# Altium schematic sheet 27C011_EMU.SchDoc
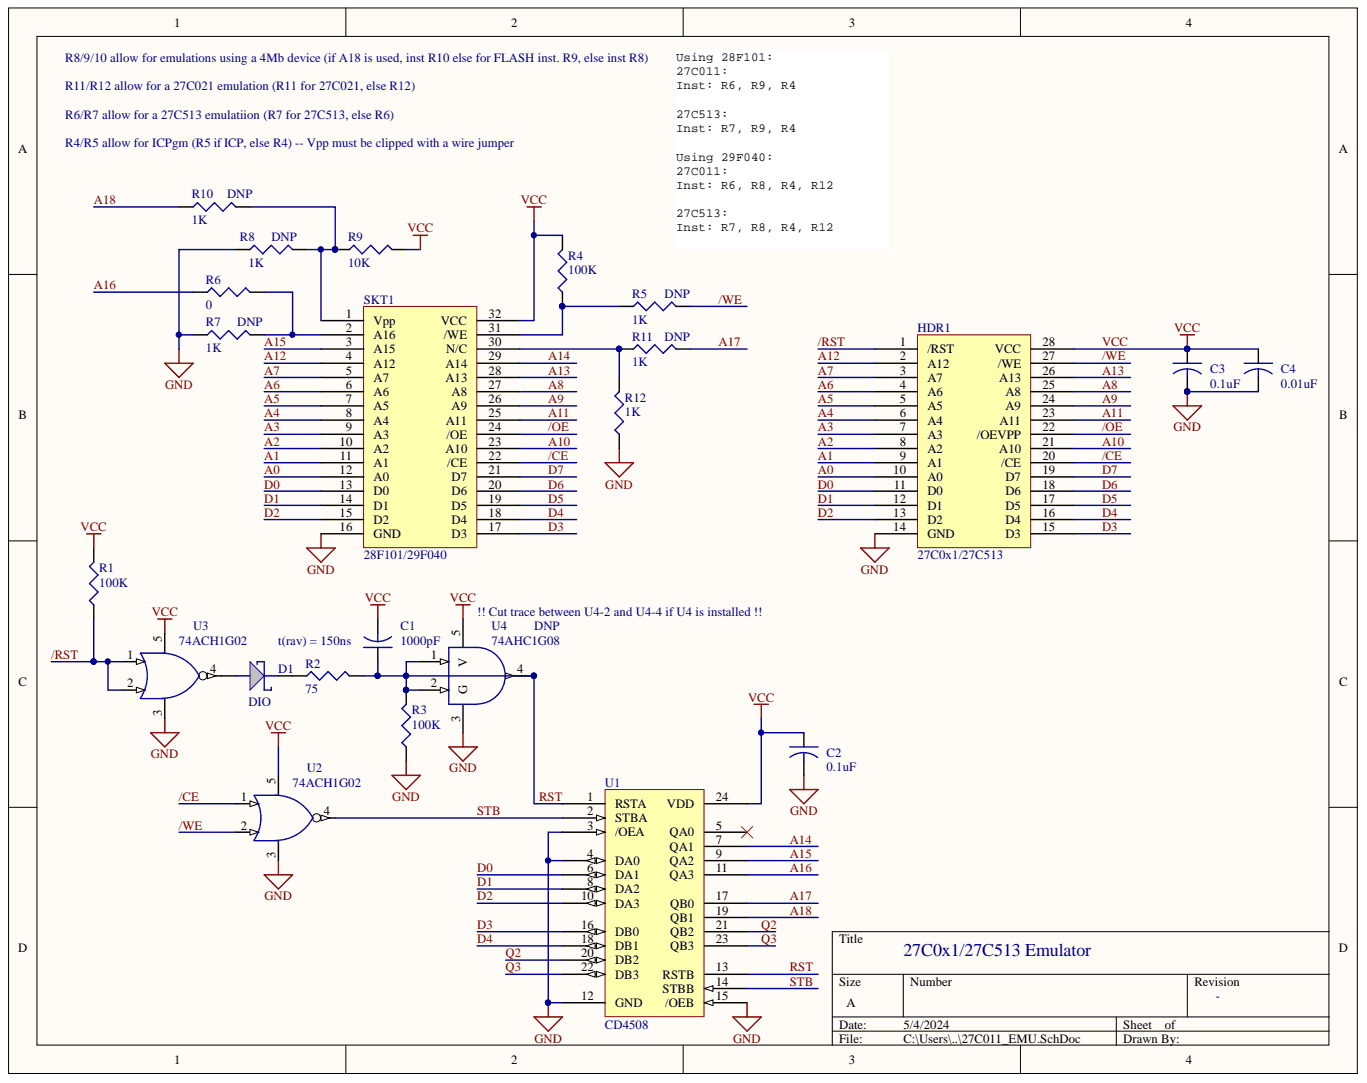
[diagram p0: 27C011_EMU.SchDoc]

## Parts
C1  1000pF  [0402]
C2  0.1uF  [0805]
C3  0.1uF  [0805]
C4  0.01uF  [0805]
D1  DIO  [SOD123]
HDR1  27C0x1/27C513  [DIP28_BOTHDR]
R1  100K  [0402]
R2  75  [0402]
R3  100K  [0402]
R4  100K  [0402]
R5  1K  [0402]
R6  0  [0402]
R7  1K  [0402]
R8  1K  [0402]
R9  10K  [0402]
R10  1K  [0402]
R11  1K  [0402]
R12  1K  [0402]
SKT1  28F101/29F040  [DIP32]
U1  CD4508  [TSSOP-24]
U2  74ACH1G02  [SC70-5]
U3  74ACH1G02  [SC70-5]
U4  74AHC1G08  [SC70-5]

## Nets
GND: C2.2 C3.2 C4.2 HDR1.14(GND) R3.1 R7.1 R8.1 R12.1 SKT1.16(GND) U1.3(/OEA) U1.4(DA0) U1.12(GND) U1.15(/OEB) U2.3 U3.3 U4.3
/CE: HDR1.20(/CE) SKT1.22(/CE) U2.1
/OE: HDR1.22(/OEVPP) SKT1.24(/OE)
/RST: HDR1.1(/RST) R1.1 U3.1 U3.2
/WE: HDR1.27(/WE) R5.2 U2.2
A0: HDR1.10(A0) SKT1.12(A0)
A1: HDR1.9(A1) SKT1.11(A1)
A2: HDR1.8(A2) SKT1.10(A2)
A3: HDR1.7(A3) SKT1.9(A3)
A4: HDR1.6(A4) SKT1.8(A4)
A5: HDR1.5(A5) SKT1.7(A5)
A6: HDR1.4(A6) SKT1.6(A6)
A7: HDR1.3(A7) SKT1.5(A7)
A8: HDR1.25(A8) SKT1.27(A8)
A9: HDR1.24(A9) SKT1.26(A9)
A10: HDR1.21(A10) SKT1.23(A10)
A11: HDR1.23(A11) SKT1.25(A11)
A12: HDR1.2(A12) SKT1.4(A12)
A13: HDR1.26(A13) SKT1.28(A13)
A14: SKT1.29(A14) U1.7(QA1)
A15: SKT1.3(A15) U1.9(QA2)
A16: R6.1 U1.11(QA3)
A17: R11.2 U1.17(QB0)
A18: R10.1 U1.19(QB1)
D0: HDR1.11(D0) SKT1.13(D0) U1.6(DA1)
D1: HDR1.12(D1) SKT1.14(D1) U1.8(DA2)
D2: HDR1.13(D2) SKT1.15(D2) U1.10(DA3)
D3: HDR1.15(D3) SKT1.17(D3) U1.16(DB0)
D4: HDR1.16(D4) SKT1.18(D4) U1.18(DB1)
D5: HDR1.17(D5) SKT1.19(D5)
D6: HDR1.18(D6) SKT1.20(D6)
D7: HDR1.19(D7) SKT1.21(D7)
Q2: U1.20(DB2) U1.21(QB2)
Q3: U1.22(DB3) U1.23(QB3)
RST: C1.1 R2.2 R3.2 U1.1(RSTA) U1.13(RSTB) U4.1 U4.2(G) U4.4
STB: U1.2(STBA) U1.14(STBB) U2.4
VCC: C1.2 C2.1 C3.1 C4.1 HDR1.28(VCC) R1.2 R4.2 R9.2 SKT1.32(VCC) U1.24(VDD) U2.5 U3.5 U4.5(V)
NetD1_1: D1.1 R2.1
NetD1_2: D1.2 U3.4
NetR4_1: R4.1 R5.1 SKT1.31(/WE)
NetR6_2: R6.2 R7.2 SKT1.2(A16)
NetR8_2: R8.2 R9.1 R10.2 SKT1.1(Vpp)
NetR11_1: R11.1 R12.2 SKT1.30(N/C)

Not connected: U1 5(QA0)

## Library data of the parts
C1 = CAP 0805: CAP, CER, 0402, 50V, 0.0022uF; MFR: Samsung EM; MPN: CL05B222KB5NNND; VOLTAGE: 50; ALT-MFR: -; ALT-MPN: -; Component Kind: Standard; DNP: -; Library Name: Galaxy SCH-jem-1.08.SchLib
C2 C3 = CAP 0805: CAP, TANT, 0805, 50V, 0.1uF; MFR: Samsung EM; MPN: CL21B104KCFSFNG; ALT-MFR: -; ALT-MPN: -; Component Kind: Standard; DNP: -; Library Name: Galaxy SCH-jem-1.08.SchLib
C4 = CAP 0805: CAP, TANT, 0805, 50V, 0.01uF; MFR: Samsung EM; MPN: CL21B103KBANNNL; ALT-MFR: -; ALT-MPN: -; Component Kind: Standard; DNP: -; Library Name: Galaxy SCH-jem-1.08.SchLib
D1 = DIODE_SCHOTTKY_SOD123: DIODE, SHTKY; MFR: Rohm; MPN: RB168MM-40TFTR; ALT-MPN: -; Component Kind: Standard; DNP: -; NOTES: -; NOTES2: -; PRI-MPN: -; Library Name: Galaxy SCH-jem-1.08.SchLib
HDR1 = 25C513: HDR, IC, DIP, 28p; ALT-MFR: Aries; ALT-MPN: 28-600-20; MFR: Ares; MPN: 28-600-10; Component Kind: Standard; Library Name: Galaxy SCH-jem-1.08.SchLib
R1 R3 R4 = R0805: RES, CHP, 0402, 1%, 100K; MFR: Yageo; MPN: RC0402FR-07100KL; ALT-MPN: -; Component Kind: Standard; DNP: -; NOTES: -; NOTES2: -; PRI-MPN: -; Library Name: Galaxy SCH-jem-1.08.SchLib
R2 = R0805: RES, CHP, 0402, 1%, 75; MFR: Yageo; MPN: RC0402FR-0775RL; ALT-MPN: -; Component Kind: Standard; DNP: -; NOTES: -; NOTES2: -; PRI-MPN: -; Library Name: Galaxy SCH-jem-1.08.SchLib
R5 R7 R8 R10-R12 = R0805: RES, CHP, 0402, 1%, 1K; MFR: Yageo; MPN: RC0402FR-071KL; ALT-MPN: -; Component Kind: Standard; NOTES: -; NOTES2: -; PRI-MPN: -; Library Name: Galaxy SCH-jem-1.08.SchLib
  R5 R7 R8 R10 R11: DNP: DNP
  R12: DNP: -
R6 = R0805: RES, CHP, 0402, 1%, 0; MFR: Yageo; MPN: RC0402FR-070RL; ALT-MPN: -; Component Kind: Standard; DNP: -; NOTES: -; NOTES2: -; PRI-MPN: -; Library Name: Galaxy SCH-jem-1.08.SchLib
R9 = R0805: RES, CHP, 0402, 1%, 10K; MFR: Yageo; MPN: RC0402FR-0710KL; ALT-MPN: -; Component Kind: Standard; DNP: -; NOTES: -; NOTES2: -; PRI-MPN: -; Library Name: Galaxy SCH-jem-1.08.SchLib
SKT1 = 28F010: SKT, DIP, 0.1" PTCH, 32p; MFR: Mill-Max; MPN: 110-99-632-41-001000; NOTES: socket for 28F101 or equivalent; NOTES2: IC, FLASH, 1Mb, x8, DIP; Component Kind: Standard; DNP: -; Library Name: Galaxy SCH-jem-1.08.SchLib
U1 = CD4508BPW: IC, LGC, LAT, DUAL, 4B; MFR: TI; Component Kind: Standard; DNP: -; MPN: CD4508BPW; Library Name: Galaxy SCH-jem-1.08.SchLib
U2 U3 = 74ACH1G02DBV+5V: IC,GATE,2IN,NOR,SNGL; MFR: TI; MPN: SN74AHC1G02DCKR; ALT-MPN: -; Component Kind: Standard; DNP: -; NOTES: -; NOTES2: -; PRI-MPN: -; Library Name: Galaxy SCH-jem-1.08.SchLib
U4 = 74AHC1G08+5V: IC,GATE,2IN,AND,SNGL,; MFR: TI; MPN: SN74AHC1G08DCKR; ALT-MPN: -; Component Kind: Standard; DNP: DNP; NOTES: -; NOTES2: -; PRI-MPN: -; Library Name: Galaxy SCH-jem-1.08.SchLib
